# Altium schematic sheet LAN.SchDoc
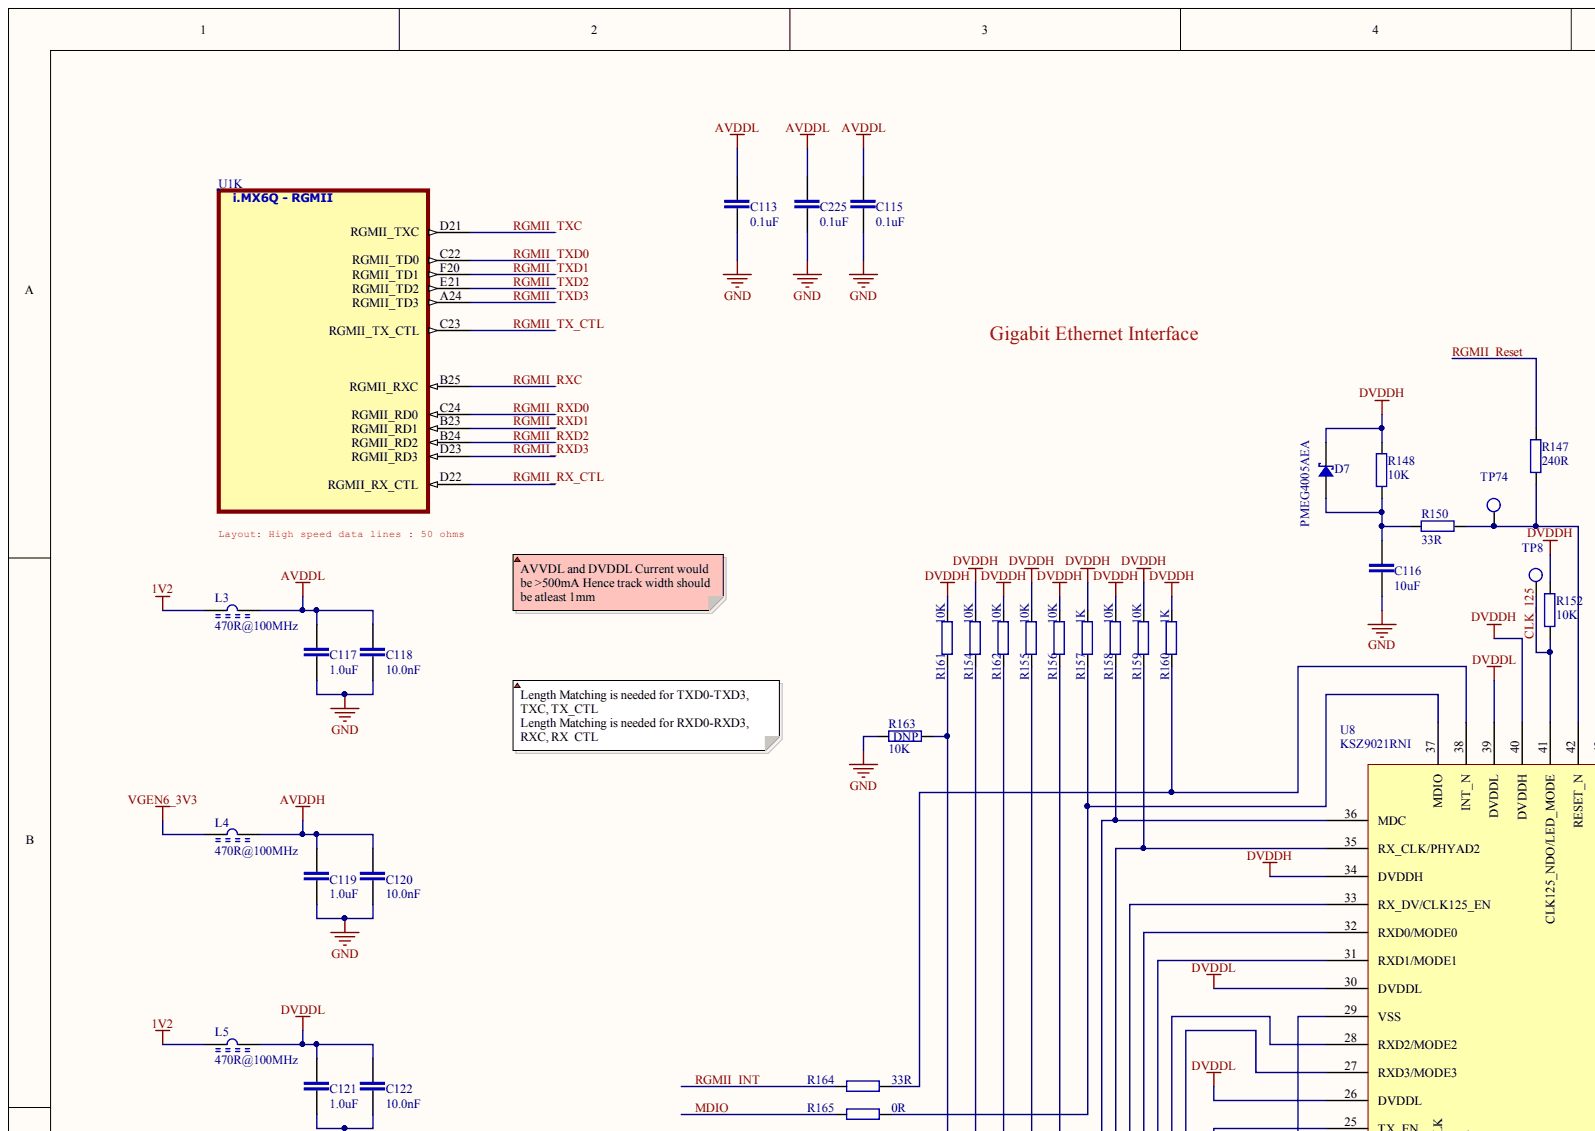
[diagram p0: LAN.SchDoc, top left]
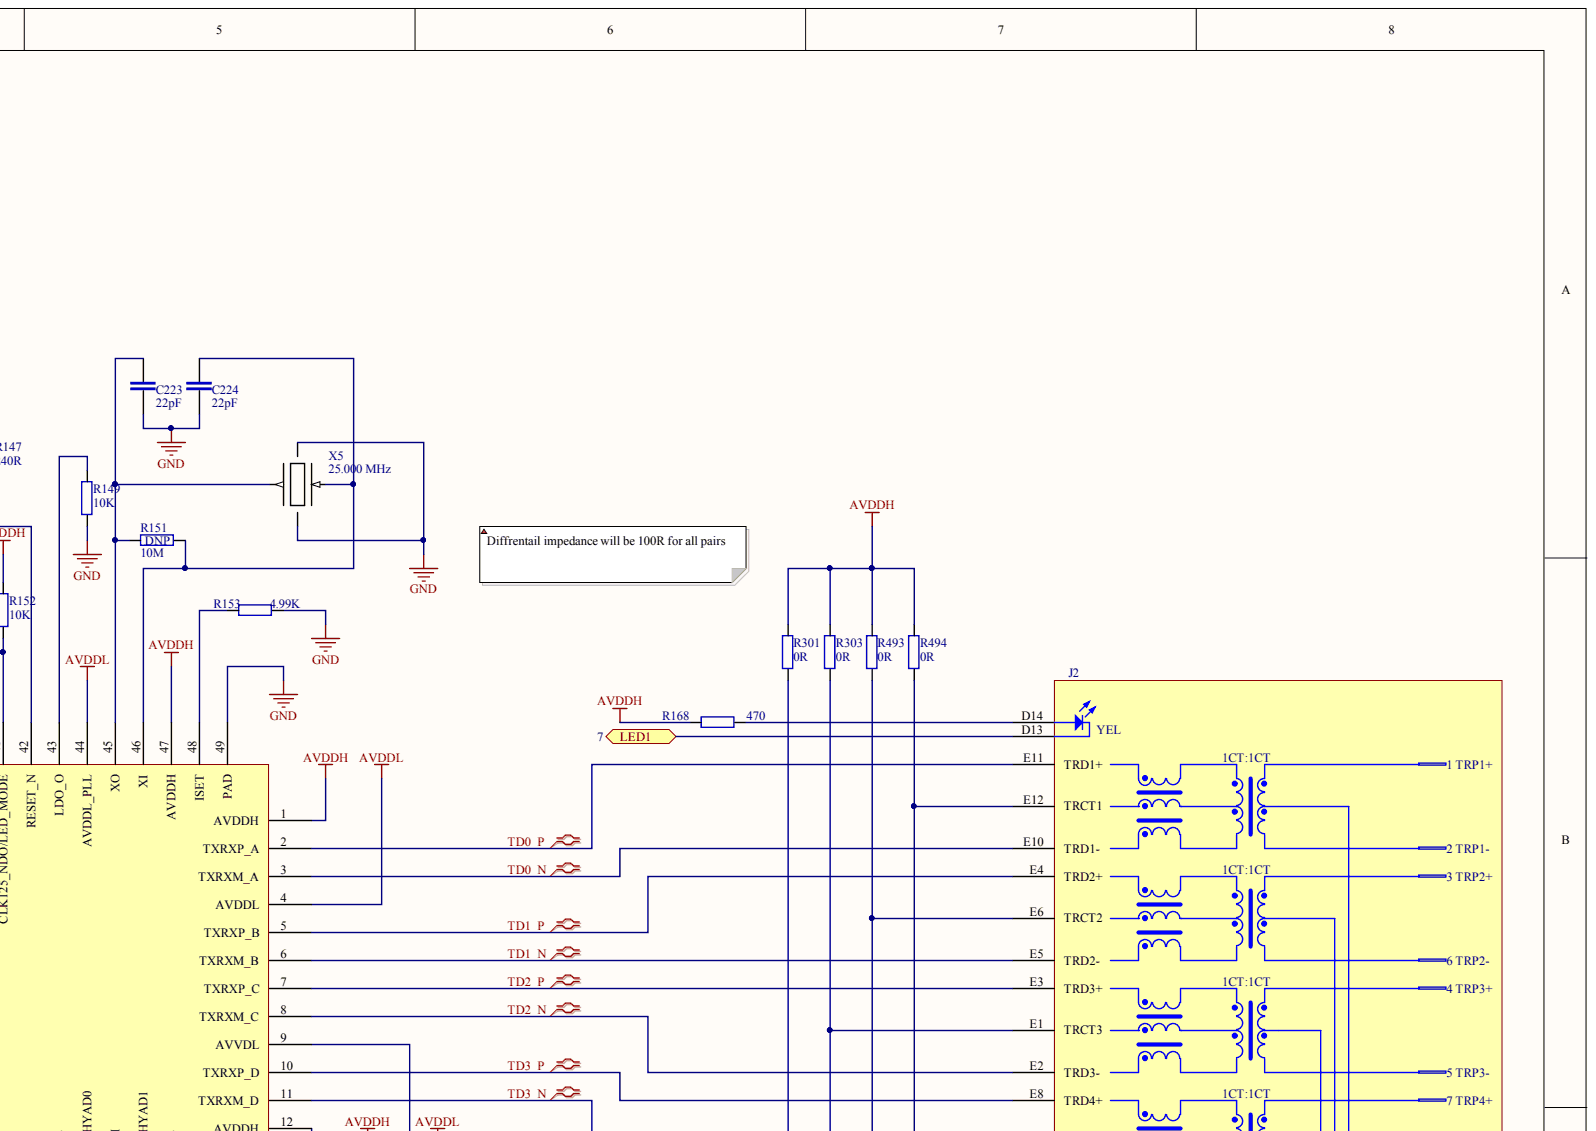
[diagram p1: LAN.SchDoc, top right]
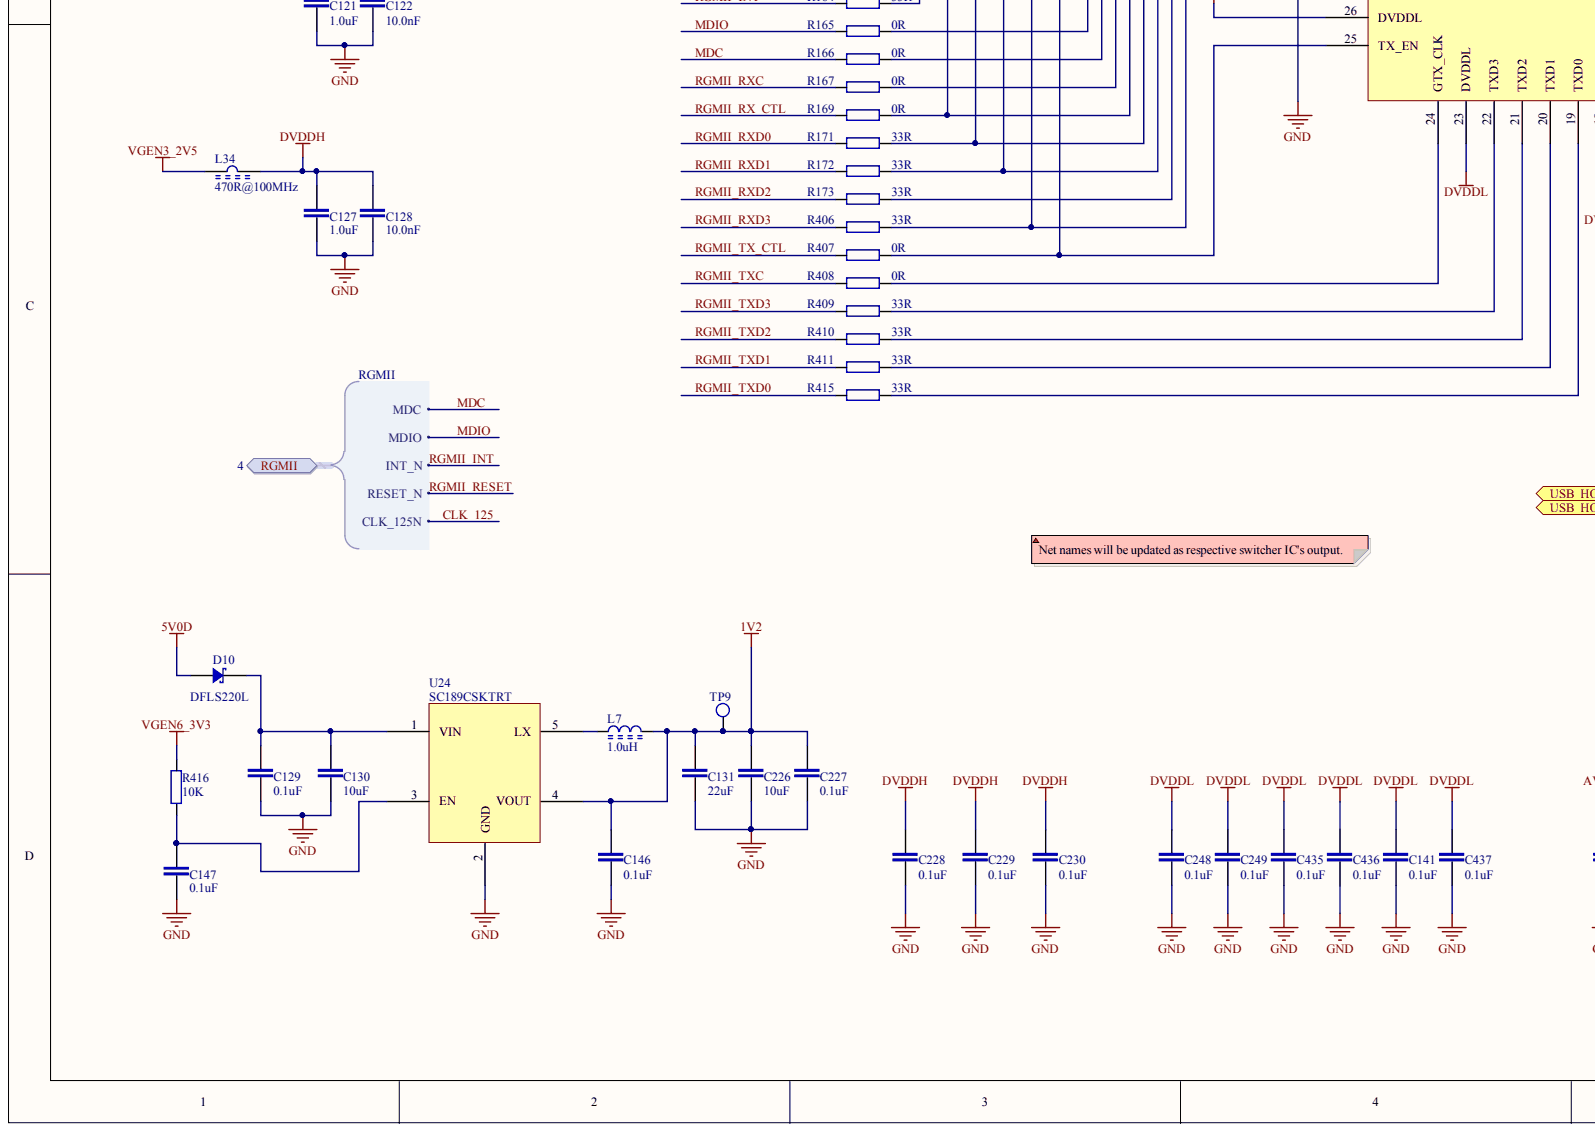
[diagram p2: LAN.SchDoc, bottom left]
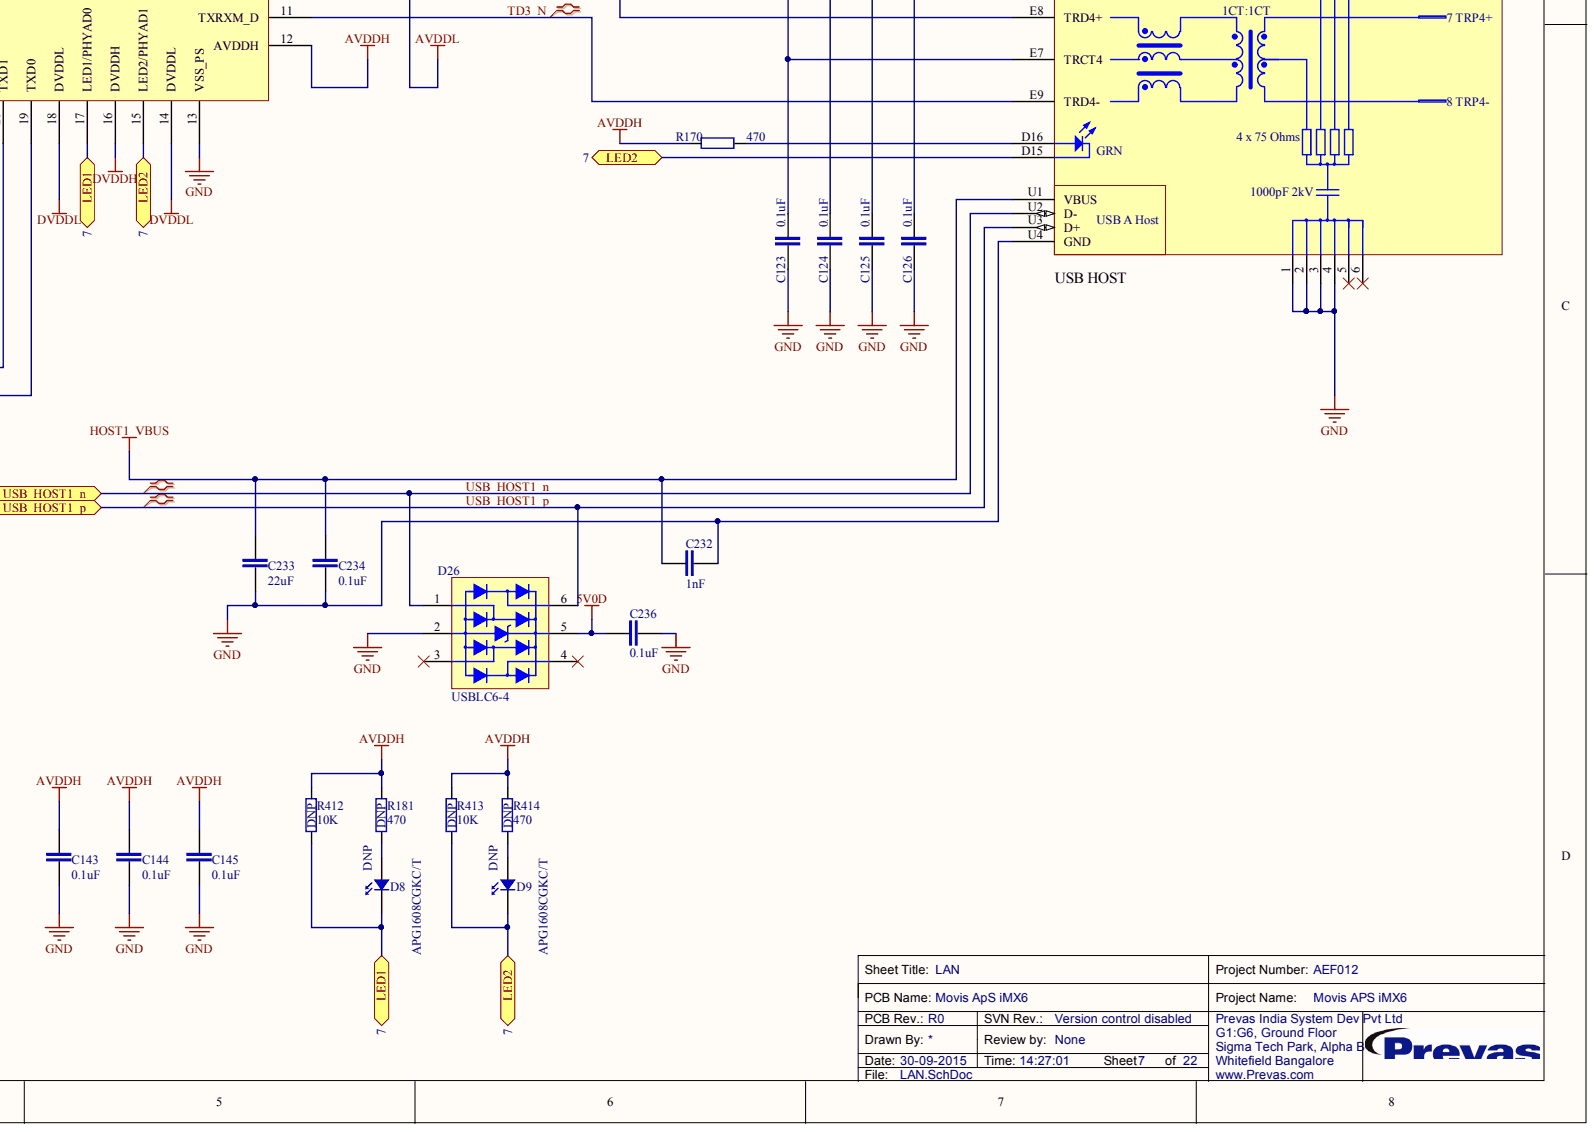
[diagram p3: LAN.SchDoc, bottom right]

## Parts
C113  0.1uF  [CAPC1005X55N]
C115  0.1uF  [CAPC1005X55N]
C116  10uF  [CAPC1608X95N]
C117  1.0uF  [CAPC1608X90N]
C118  10.0nF  [CAPC1005X55N]
C119  1.0uF  [CAPC1608X90N]
C120  10.0nF  [CAPC1005X55N]
C121  1.0uF  [CAPC1608X90N]
C122  10.0nF  [CAPC1005X55N]
C123  0.1uF  [CAPC1005X55N]
C124  0.1uF  [CAPC1005X55N]
C125  0.1uF  [CAPC1005X55N]
C126  0.1uF  [CAPC1005X55N]
C127  1.0uF  [CAPC1608X90N]
C128  10.0nF  [CAPC1005X55N]
C129  0.1uF  [CAPC1005X55N]
C130  10uF  [CAPC1608X95N]
C131  22uF  [CAPC3216X180N]
C141  0.1uF  [CAPC1005X55N]
C143  0.1uF  [CAPC1005X55N]
C144  0.1uF  [CAPC1005X55N]
C145  0.1uF  [CAPC1005X55N]
C146  0.1uF  [CAPC1005X55N]
C147  0.1uF  [CAPC1005X55N]
C223  22pF  [CAPC1005X55N]
C224  22pF  [CAPC1005X55N]
C225  0.1uF  [CAPC1005X55N]
C226  10uF  [CAPC1608X95N]
C227  0.1uF  [CAPC1005X55N]
C228  0.1uF  [CAPC1005X55N]
C229  0.1uF  [CAPC1005X55N]
C230  0.1uF  [CAPC1005X55N]
C232  1nF  [CAPC1005X55N]
C233  22uF  [CAPC3216X180N]
C234  0.1uF  [CAPC1005X55N]
C236  0.1uF  [CAPC1005X55N]
C248  0.1uF  [CAPC1005X55N]
C249  0.1uF  [CAPC1005X55N]
C435  0.1uF  [CAPC1005X55N]
C436  0.1uF  [CAPC1005X55N]
C437  0.1uF  [CAPC1005X55N]
D7  PMEG4005AEA  [SOD2512X110N]
D8  APG1608CGKC/T  Fitted: DNP  [Kingbright_APG1608CGKC-T]
D9  APG1608CGKC/T  Fitted: DNP  [Kingbright_APG1608CGKC-T]
D10  DFLS220L  [SODFL3718X100N]
D26  USBLC6-4  [SOT23-6L]
J2  0821-1X1T-43-F_1  [MagJack_43_1X1T+1USBA]  (value not drawn)
L3  470R@100MHz  [INDC4516X180N]
L4  470R@100MHz  [INDC4516X180N]
L5  470R@100MHz  [INDC4516X180N]
L7  1.0uH  [IND_LPS3015]
L34  470R@100MHz  [INDC4516X180N]
R147  240R  [RESC1608X55N]
R148  10K  [RESC1608X55N]
R149  10K  [RESC1608X55N]
R150  33R  [RESC1608X55N]
R151  10M  Fitted: DNP  [RESC1608X55N]
R152  10K  [RESC1608X55N]
R153  4.99K  [RESC1608X55N]
R154  10K  [RESC1608X55N]
R155  10K  [RESC1608X55N]
R156  10K  [RESC1608X55N]
R157  1K  [RESC1608X55N]
R158  10K  [RESC1608X55N]
R159  10K  [RESC1608X55N]
R160  1K  [RESC1608X55N]
R161  10K  [RESC1608X55N]
R162  10K  [RESC1608X55N]
R163  10K  Fitted: DNP  [RESC1608X55N]
R164  33R  [RESC1608X55N]
R165  0R  [RESC1608X55N]
R166  0R  [RESC1608X55N]
R167  0R  [RESC1608X55N]
R168  470  [RESC1608X55N]
R169  0R  [RESC1608X55N]
R170  470  [RESC1608X55N]
R171  33R  [RESC1608X55N]
R172  33R  [RESC1608X55N]
R173  33R  [RESC1608X55N]
R181  470  Fitted: DNP  [RESC1608X55N]
R301  0R  [RESC1608X55N]
R303  0R  [RESC1608X55N]
R406  33R  [RESC1608X55N]
R407  0R  [RESC1608X55N]
R408  0R  [RESC1608X55N]
R409  33R  [RESC1608X55N]
R410  33R  [RESC1608X55N]
R411  33R  [RESC1608X55N]
R412  10K  Fitted: DNP  [RESC1608X55N]
R413  10K  Fitted: DNP  [RESC1608X55N]
R414  470  Fitted: DNP  [RESC1608X55N]
R415  33R  [RESC1608X55N]
R416  10K  [RESC1608X55N]
R493  0R  [RESC1608X55N]
R494  0R  [RESC1608X55N]
TP8  Testpoint  [TP_SMD]  (value not drawn)  (no BOM)
TP9  Testpoint  [TP_SMD]  (value not drawn)  (no BOM)
TP74  Testpoint  [TP_SMD]  (value not drawn)  (no BOM)
U1  MCIMX6Q6AVT10AC  [BGA624C80P25X25_2100X2100X160]  (value not drawn)  (part K)
U8  KSZ9021RN  source_manufacturer_1_pn: KSZ9021RNI  [QFN50P700X700X90-49VKKD8N]
U24  SC189  Part Number: SC189CSKTRT  [SOT95P280X145-5N]
X5  25.000 MHz  [OSCCC320X500X110N]

## Ports
LED1, LED2, RGMII, RGMII.CLK_125N, RGMII.INT_N, RGMII.MDC, RGMII.MDIO, RGMII.RESET_N, USB_HOST1_N, USB_HOST1_P

## Nets
1V2: C131.2 C146.2 C226.2 C227.2 L3.1 L5.1 L7.2 TP9.1 U24.4(VOUT)
5V0D: C236.2 D10.A D26.5
AVDDH: C119.2 C120.2 C143.1 C144.1 C145.1 L4.2 R168.2 R170.2 R181.2 R301.2 R303.2 R412.1 R413.1 R414.2 R493.2 R494.2 U8.1(AVDDH) U8.12(AVDDH) U8.47(AVDDH)
AVDDL: C113.1 C115.1 C117.2 C118.2 C225.1 L3.2 U8.4(AVDDL) U8.9(AVVDL) U8.44(AVDDL_PLL)
DVDDH: C127.2 C128.2 C228.1 C229.1 C230.1 D7.C L34.2 R148.1 R152.1 R154.1 R155.1 R156.1 R157.2 R158.1 R159.1 R160.2 R161.1 R162.1 U8.16(DVDDH) U8.34(DVDDH) U8.40(DVDDH)
DVDDL: C121.2 C122.2 C141.1 C248.1 C249.1 C435.1 C436.1 C437.1 L5.2 U8.14(DVDDL) U8.18(DVDDL) U8.23(DVDDL) U8.26(DVDDL) U8.30(DVDDL) U8.39(DVDDL)
GND: C113.2 C115.2 C116.1 C117.1 C118.1 C119.1 C120.1 C121.1 C122.1 C123.2 C124.2 C125.2 C126.2 C127.1 C128.1 C129.1 C130.1 C131.1 C141.2 C143.2 C144.2 C145.2 C146.1 C147.1 C223.1 C224.1 C225.2 C226.1 C227.1 C228.2 C229.2 C230.2 C232.2 C233.1 C234.1 C236.1 C248.2 C249.2 C435.2 C436.2 C437.2 D26.2 J2.1 J2.2 J2.3 J2.4 J2.U4(GND) R149.2 R153.2 R163.1 U8.13(VSS_PS) U8.29(VSS) U8.49(PAD) U24.2(GND) X5.2 X5.4
HOST1_VBUS: C232.1 C233.2 C234.2 J2.U1(VBUS)
VGEN3_2V5: L34.1
VGEN6_3V3: L4.1 R416.2
CLK_125: R152.2 TP8.1 U8.41(CLK125_NDO/LED_MODE) ; port RGMII
LED1: D8.C J2.D13 R412.2 U8.17(LED1/PHYAD0) ; port LED1
LED2: D9.C J2.D15 R413.2 U8.15(LED2/PHYAD1) ; port LED2
MDC: R166.2 ; port RGMII
MDIO: R165.2 ; port RGMII
RGMII_INT: R164.1 ; port RGMII
RGMII_RESET: R147.2 ; port RGMII
RGMII_RX_CTL: R169.2 U1.D22(RGMII_RX_CTL)
RGMII_RXC: R167.2 U1.B25(RGMII_RXC)
RGMII_RXD0: R171.1 U1.C24(RGMII_RD0)
RGMII_RXD1: R172.1 U1.B23(RGMII_RD1)
RGMII_RXD2: R173.1 U1.B24(RGMII_RD2)
RGMII_RXD3: R406.1 U1.D23(RGMII_RD3)
RGMII_TX_CTL: R407.2 U1.C23(RGMII_TX_CTL)
RGMII_TXC: R408.2 U1.D21(RGMII_TXC)
RGMII_TXD0: R415.1 U1.C22(RGMII_TD0)
RGMII_TXD1: R411.1 U1.F20(RGMII_TD1)
RGMII_TXD2: R410.1 U1.E21(RGMII_TD2)
RGMII_TXD3: R409.1 U1.A24(RGMII_TD3)
TD0_N: J2.E10(TRD1-) U8.3(TXRXM_A)
TD0_P: J2.E11(TRD1+) U8.2(TXRXP_A)
TD1_N: J2.E5(TRD2-) U8.6(TXRXM_B)
TD1_P: J2.E4(TRD2+) U8.5(TXRXP_B)
TD2_N: J2.E2(TRD3-) U8.8(TXRXM_C)
TD2_P: J2.E3(TRD3+) U8.7(TXRXP_C)
TD3_N: J2.E9(TRD4-) U8.11(TXRXM_D)
TD3_P: J2.E8(TRD4+) U8.10(TXRXP_D)
USB_HOST1_N: D26.1 J2.U2(D-) ; port USB_HOST1_N
USB_HOST1_P: D26.6 J2.U3(D+) ; port USB_HOST1_P
NetC116_2: C116.2 D7.A R148.2 R150.1
NetC123_1: C123.1 J2.E7(TRCT4) R301.1
NetC124_1: C124.1 J2.E1(TRCT3) R303.1
NetC125_1: C125.1 J2.E6(TRCT2) R493.1
NetC126_1: C126.1 J2.E12(TRCT1) R494.1
NetC129_2: C129.2 C130.2 D10.C U24.1(VIN)
NetC147_2: C147.2 R416.1 U24.3(EN)
NetC223_2: C223.2 R151.1 U8.45(XO) X5.3
NetC224_2: C224.2 R151.2 U8.46(XI) X5.1
NetD8_A: D8.A R181.1
NetD9_A: D9.A R414.1
NetJ2_D14: J2.D14 R168.1
NetJ2_D16: J2.D16 R170.1
NetL7_1: L7.1 U24.5(LX)
NetR147_1: R147.1 R150.2 TP74.1 U8.42(RESET_N)
NetR149_1: R149.1 U8.43(LDO_O)
NetR153_1: R153.1 U8.48(ISET)
NetR154_2: R154.2 R171.2 U8.32(RXD0/MODE0)
NetR155_2: R155.2 R406.2 U8.27(RXD3/MODE3)
NetR156_2: R156.2 R407.1 U8.25(TX_EN)
NetR157_1: R157.1 R165.1 U8.37(MDIO)
NetR158_2: R158.2 R166.1 U8.36(MDC)
NetR159_2: R159.2 R167.1 U8.35(RX_CLK/PHYAD2)
NetR160_1: R160.1 R164.2 U8.38(INT_N)
NetR161_2: R161.2 R163.2 R169.1 U8.33(RX_DV/CLK125_EN)
NetR162_2: R162.2 R172.2 U8.31(RXD1/MODE1)
NetR173_2: R173.2 U8.28(RXD2/MODE2)
NetR408_1: R408.1 U8.24(GTX_CLK)
NetR409_2: R409.2 U8.22(TXD3)
NetR410_2: R410.2 U8.21(TXD2)
NetR411_2: R411.2 U8.20(TXD1)
NetR415_2: R415.2 U8.19(TXD0)

Not connected: D26 3 4; J2 5 6

## Library data of the parts
C113 C115 C123-C126 C129 C141 C143-C147 C225 C227-C230 C234 C236 C248 C249 C435-C437 = INNISI-73: CAP CER 0.1UF 50V 10% X5R 0402; ref_part_type: /capacitor/non_polarized/ceramic/; source_manufacturer_1: TDK Corporation; source_manufacturer_1_pn: C1005X5R1H104K050BB; cae_altium_pcb_status: Prototype; Database Table Name: altium_default; db_rev: 7569; elec_temp_range: -55 to +85 C; phy_height: 0.55mm; phy_mounting: SMD; phy_packaging_type: 0402; ref_datasheet: INNISI-71; cae_altium_sch_status: Prototype; Component Kind: Standard; ComponentLink1Description: Datasheet; ComponentLink1URL: https://cop201.prevas.dk/viewvc/datasheet/INNISI-71.pdf?root=hw_components&view=co; db_created: 2015-08-03 03:34:59.0; db_last_updated: 03-08-2015 03:35:01; db_local_id: INNISI-73; db_status: Prototype; elec_tolerance: ±10%; elec_value: 0.1uF; elec_voltage_rating: 50V; phy_material: X5R; ref_keyword: CAP CER 0.1UF 50V 10% X5R 0402; Library Name: altium_mysql.dblib
  C234 C236: Mounted: Yes
C116 C130 C226 = innisi-78: CAP CER 10UF 16V 10% X5R 0603; ref_part_type: /capacitor/non_polarized/ceramic/; source_manufacturer_1: Murata; source_manufacturer_1_pn: GRM188R61C106KAALD; cae_altium_pcb_status: Prototype; Database Table Name: altium_default; db_rev: 7574; elec_temp_range: -55 to +85C; phy_height: 0.95mm; phy_mounting: SMD; phy_packaging_type: 0603; ref_datasheet: INNISI-75; ref_keyword: Ceramic capacitor X5R 0603; cae_altium_sch_status: Prototype; Component Kind: Standard; ComponentLink1Description: Datasheet; ComponentLink1URL: https://cop201.prevas.dk/viewvc/datasheet/INNISI-75.pdf?root=hw_components&view=co; db_created: 2015-08-03 05:08:42.0; db_last_updated: 03-08-2015 05:08:44; db_local_id: INNISI-78; db_status: Prototype; elec_tolerance: 10%; elec_value: 10uF; elec_voltage_rating: 16V; phy_material: X5R; Library Name: altium_mysql.dblib
C117 C119 C121 C127 = INNISI-79: CAP CER 1UF 16V 10% X7R 0603; ref_part_type: /capacitor/non_polarized/ceramic/; source_manufacturer_1: Murata; source_manufacturer_1_pn: GRM188R71C105KE15D; cae_altium_pcb_status: Prototype; Database Table Name: altium_default; db_rev: 7576; elec_temp_range: -55 to +125; phy_height: 0.90mm; phy_mounting: SMD; phy_packaging_type: 0603 (1608 Metric); ref_datasheet: INNISI-33; ref_keyword: Ceramic Capacitor 0603; cae_altium_sch_status: Prototype; Component Kind: Standard; ComponentLink1Description: Datasheet; ComponentLink1URL: https://cop201.prevas.dk/viewvc/datasheet/INNISI-33.pdf?root=hw_components&view=co; db_created: 2015-08-03 05:39:06.0; db_last_updated: 03-08-2015 05:39:08; db_local_id: INNISI-79; db_status: Prototype; elec_tolerance: 10%; elec_value: 1.0uF; elec_voltage_rating: 16V; phy_material: X7R; Library Name: altium_mysql.dblib
C118 C120 C122 C128 = INNISI-82: CAP CER 10nF 50V 10% X7R 0402; ref_part_type: /capacitor/non_polarized/ceramic/; source_manufacturer_1: Yageo; source_manufacturer_1_pn: CC0402KRX7R9BB103; cae_altium_pcb_status: Prototype; Database Table Name: altium_default; db_rev: 7579; elec_temp_range: -55 to +125C; phy_height: 0.55mm; phy_mounting: SMD; phy_packaging_type: 0402; ref_datasheet: INNISI-72; ref_keyword: Ceramic capacitor; cae_altium_sch_status: Prototype; Component Kind: Standard; ComponentLink1Description: Datasheet; ComponentLink1URL: https://cop201.prevas.dk/viewvc/datasheet/INNISI-72.pdf?root=hw_components&view=co; db_created: 2015-08-03 05:52:48.0; db_last_updated: 03-08-2015 05:52:50; db_local_id: INNISI-82; db_status: Prototype; elec_tolerance: 10%; elec_value: 10.0nF; elec_voltage_rating: 50V; phy_material: X7R; Library Name: altium_mysql.dblib
C131 C233 = INNISI-77: CAP CER 22UF 25V 10% X5R 1206; ref_part_type: /capacitor/non_polarized/ceramic/; source_manufacturer_1: Murata Electronics; source_manufacturer_1_pn: GRM31CR61E226KE15L; cae_altium_pcb_status: Prototype; Database Table Name: altium_default; db_rev: 7573; elec_temp_range: -55 to +85 C; phy_height: 1.8mm; phy_mounting: SMD; phy_packaging_type: 1206; ref_datasheet: INNISI-74; ref_keyword: Multilayer chip capacitor; cae_altium_sch_status: Prototype; Component Kind: Standard; ComponentLink1Description: Datasheet; ComponentLink1URL: https://cop201.prevas.dk/viewvc/datasheet/INNISI-74.pdf?root=hw_components&view=co; db_created: 2015-08-03 04:56:21.0; db_last_updated: 03-08-2015 04:56:22; db_local_id: INNISI-77; db_status: Prototype; elec_tolerance: 10%; elec_value: 22uF; elec_voltage_rating: 25V; phy_material: X5R; Library Name: altium_mysql.dblib
C223 C224 = INNISI-83: CAP CER 22PF 50V 5% NP0 0402; ref_part_type: /capacitor/non_polarized/ceramic/; source_manufacturer_1: Murata; source_manufacturer_1_pn: GCM1555C1H220JA16D; cae_altium_pcb_status: Prototype; Database Table Name: altium_default; db_rev: 7582; elec_temp_range: -55 to +125C; phy_height: 0.55mm; phy_material: C0G; phy_mounting: SMD; phy_packaging_type: 0402; ref_datasheet: INNISI-76; ref_keyword: Ceramic capacitor; cae_altium_sch_status: Prototype; Component Kind: Standard; ComponentLink1Description: Datasheet; ComponentLink1URL: https://cop201.prevas.dk/viewvc/datasheet/INNISI-76.pdf?root=hw_components&view=co; db_created: 2015-08-03 06:17:55.0; db_last_updated: 03-08-2015 06:17:58; db_local_id: INNISI-83; db_status: Prototype; elec_tolerance: 5%; elec_value: 22pF; elec_voltage_rating: 50V; Library Name: altium_mysql.dblib
C232 = INNISI-84: CAP CER 1nF 50V 10% X7R 0402; ref_part_type: /capacitor/non_polarized/ceramic/; source_manufacturer_1: Murata; source_manufacturer_1_pn: GRM155R71H102KA01J; cae_altium_pcb_status: Prototype; Database Table Name: altium_default; db_rev: 7585; elec_temp_range: -55 to +125C; phy_height: 0.55mm; phy_mounting: SMD; phy_packaging_type: 0402; ref_datasheet: INNISI-73; ref_keyword: Ceramic capacitor X7R 0402; cae_altium_sch_status: Prototype; Component Kind: Standard; ComponentLink1Description: Datasheet; ComponentLink1URL: https://cop201.prevas.dk/viewvc/datasheet/INNISI-73.pdf?root=hw_components&view=co; db_created: 2015-08-03 06:26:19.0; db_last_updated: 03-08-2015 06:26:21; db_local_id: INNISI-84; db_status: Prototype; elec_tolerance: 10%; elec_value: 1nF; elec_voltage_rating: 50V; Mounted: Yes; phy_material: X7R; Library Name: altium_mysql.dblib
D7 = MASD-133: Diode Very low VF SOD323; ref_part_type: /diode/schottky/; source_manufacturer_1: Philips; cae_altium_pcb_status: Prototype; Database Table Name: altium_default; db_rev: 2165; elec_current_rating: 500mA; elec_temp_range: -65 to +150; elec_voltage_rating: 40V; phy_height: 1.1mm; phy_mounting: SMD; ref_datasheet: MASD-123; ref_keyword: Schottky Diode SMD; cae_altium_sch_status: Prototype; Component Kind: Standard; ComponentLink1Description: Datasheet; ComponentLink1URL: https://cop201.prevas.dk/viewvc/datasheet/MASD-123.pdf?root=hw_components&view=co; db_created: 2009-05-13 10:24:57; db_last_updated: 01-03-2010 14:47:58; db_local_id: MASD-133; db_status: Prototype; elec_value: PMEG4005AEA; phy_packaging_type: SOD323; source_manufacturer_1_pn: PMEG4005AEA; Library Name: altium_mysql.dblib
D8 D9 = GEPW-161: SMD LED, 570nm, 70mcd; ref_part_type: /optical/emmision/diode/; source_manufacturer_1: Kingbright; cae_altium_pcb_status: Prototype; Database Table Name: altium_default; db_rev: 3358; elec_current_rating: IF=20mA; elec_temp_range: -40 to 85; elec_voltage_rating: 2.1V; phy_height: 0.25; phy_packaging_type: 0603; ref_datasheet: GEPW-139; ref_keyword: Green; cae_altium_sch_status: Prototype; Component Kind: Standard; ComponentLink1Description: Datasheet; ComponentLink1URL: https://cop201.prevas.dk/viewvc/datasheet/GEPW-139.pdf?root=hw_components&view=co; db_created: 2011-04-26 11:17:00.0; db_last_updated: 26-04-2011 11:17:00; db_local_id: GEPW-161; db_status: Prototype; elec_frequency_rating: 570nm; elec_value: APG1608CGKC/T; phy_mounting: SMD; source_manufacturer_1_pn: APG1608CGKC/T; Library Name: altium_mysql.dblib
D10 = NIMA-225: Schottky Diode Rectifier, 2A; ref_part_type: /diode/schottky/; source_manufacturer_1: Diodes Inc; source_manufacturer_1_pn: DFLS220L-7; cae_altium_pcb_status: Prototype; Database Table Name: altium_default; db_rev: 2763; elec_temp_range: -55 to +125°C; elec_voltage_rating: 20V; phy_height: 1.0mm; phy_mounting: SMD; phy_packaging_type: PowerDI123; ref_datasheet: NIMA-165; ref_keyword: Schottky Diode SMD; cae_altium_sch_status: Prototype; Component Kind: Standard; ComponentLink1Description: Datasheet; ComponentLink1URL: https://cop201.prevas.dk/viewvc/datasheet/NIMA-165.pdf?root=hw_components&view=co; db_created: 2010-09-29 00:35:27.0; db_last_updated: 11-10-2010 13:07:30; db_local_id: NIMA-225; db_status: Prototype; elec_current_rating: 2A; elec_value: DFLS220L; Library Name: altium_mysql.dblib
D26 = NIMA-155: Dual very low capacitance ESD protection for USB 2.0 up to 480Mb/s; ref_part_type: /diode/transient/; source_manufacturer_1: STMicroelectronics; cae_altium_pcb_status: Prototype; Database Table Name: altium_default; db_rev: 1817; elec_temp_range: -40 to 125; elec_voltage_rating: 5V; phy_height: 1.45mm; phy_mounting: SMD; ref_datasheet: NIMA-115; cae_altium_sch_status: Prototype; Component Kind: Standard; ComponentLink1Description: Datasheet; ComponentLink1URL: https://cop201.prevas.dk/viewvc/datasheet/NIMA-115.pdf?root=hw_components&view=co; db_created: 2009-09-25 21:20:59; db_last_updated: 25-09-2009 21:21:20; db_local_id: NIMA-155; db_status: Prototype; elec_value: USBLC6-4; Mounted: Yes; phy_packaging_type: SOT23-6L; ref_keyword: ESD; source_manufacturer_1_pn: USBLC6-4; Library Name: altium_mysql.dblib
J2 = INNISI-29: CONN MAGJACK 2PORT 1000 BASE-T; ref_part_type: /connector/; source_manufacturer_1: Bel Fuse Inc; cae_altium_pcb_status: Prototype; Database Table Name: altium_default; db_rev: 7368; phy_mounting: Leaded; ref_keyword: RJ45 with USB A; cae_altium_sch_status: Prototype; Component Kind: Standard; ComponentLink1Description: Datasheet; ComponentLink1URL: https://cop201.prevas.dk/viewvc/datasheet/INNISI-29.pdf?root=hw_components&view=co; db_created: 2015-06-03 03:16:53.0; db_last_updated: 03-06-2015 03:16:55; db_local_id: INNISI-29; db_status: Prototype; elec_value: 0821-1X1T-43-F; ref_datasheet: INNISI-29; source_manufacturer_1_pn: 0821-1X1T-43-F; Library Name: altium_mysql.dblib
L3-L5 L34 = NIMA-36: Chip EMIFIL Inductor Type, 470R@100MHz, 0.05R@0Hz; ref_part_type: /inductor/lossy/; source_manufacturer_1: Murata; source_manufacturer_1_pn: BLM41PG471SN1L; cae_altium_pcb_status: Prototype; Database Table Name: altium_default; db_rev: 5393; elec_current_rating: 2.0A; elec_frequency_rating: 100MHz; elec_temp_range: -55 to 125; elec_tolerance: 25%; phy_height: 1.8mm; phy_material: Ferrite; phy_mounting: SMD; phy_packaging_type: 1806; ref_datasheet: NIMA-29; ref_keyword: Ferrite bead 1806; cae_altium_sch_status: Prototype; Component Kind: Standard; ComponentLink1Description: Datasheet; ComponentLink1URL: https://cop201.prevas.dk/viewvc/datasheet/NIMA-29.pdf?root=hw_components&view=co; db_created: 2009-03-20 21:04:27; db_last_updated: 05-12-2012 15:13:29; db_local_id: NIMA-36; db_status: Prototype; elec_value: 470R@100MHz; Library Name: altium_mysql.dblib
L7 = CHPE-247: Shielded Power Inductor; ref_part_type: /inductor/power/; source_manufacturer_1: Coilcraft; source_manufacturer_1_pn: LPS3015-102ML; cae_altium_pcb_status: Prototype; Database Table Name: altium_default; db_rev: 4393; elec_current_rating: 1.8A; elec_temp_range: -40 to +85°C; elec_tolerance: 20%; phy_height: 1.5mm; phy_material: Ferrite, copper; phy_mounting: SMD; ref_datasheet: GEPW-28; cae_altium_sch_status: Prototype; Component Kind: Standard; ComponentLink1Description: Datasheet; ComponentLink1URL: https://cop201.prevas.dk/viewvc/datasheet/GEPW-28.pdf?root=hw_components&view=co; db_created: 2012-03-13 14:03:37.0; db_last_updated: 13-03-2012 14:03:37; db_local_id: CHPE-247; db_status: Prototype; elec_value: 1.0uH; ref_keyword: Power inductor; Library Name: altium_mysql.dblib
R147 = INVIHA-10: RES SMD 240 OHM 1% 1/10W 0603; ref_part_type: /resistor/fixed/; source_manufacturer_1: Yageo; source_manufacturer_1_pn: RC0603FR-07240RL; cae_altium_pcb_status: Prototype; Database Table Name: altium_default; db_rev: 7589; elec_power_rating: 0.1W; elec_temp_range: -55 to +155° C; phy_height: 0.55mm; phy_packaging_type: 0603; ref_datasheet: INVIHA-2; ref_keyword: Chip Resistor; cae_altium_sch_status: Prototype; Component Kind: Standard; ComponentLink1Description: Datasheet; ComponentLink1URL: https://cop201.prevas.dk/viewvc/datasheet/INVIHA-2.pdf?root=hw_components&view=co; db_created: 2015-08-03 06:36:38.0; db_last_updated: 03-08-2015 6:36:40 AM; db_local_id: INVIHA-10; db_status: Prototype; elec_tolerance: 1%; elec_value: 240R; phy_mounting: SMD; Library Name: altium_mysql.dblib
R148 R149 R152 R154-R156 R158 R159 R161-R163 R412 R413 R416 = INVIHA-3: RES SMD 10K OHM 1% 1/10W 0603; ref_part_type: /resistor/fixed/; source_manufacturer_1: Yageo; source_manufacturer_1_pn: RC0603FR-0710KL; cae_altium_pcb_status: Prototype; Database Table Name: altium_default; db_rev: 7575; elec_power_rating: 0.1W; elec_temp_range: -55 to +155° C; phy_height: 0.55mm; phy_packaging_type: 0603; ref_datasheet: INVIHA-2; ref_keyword: Chip Resistor; cae_altium_sch_status: Prototype; Component Kind: Standard; ComponentLink1Description: Datasheet; ComponentLink1URL: https://cop201.prevas.dk/viewvc/datasheet/INVIHA-2.pdf?root=hw_components&view=co; db_created: 2015-08-03 05:39:03.0; db_last_updated: 03-08-2015 5:39:05 AM; db_local_id: INVIHA-3; db_status: Prototype; elec_tolerance: 1%; elec_value: 10K; phy_mounting: SMD; Library Name: altium_mysql.dblib
  R148 R149 R152 R154-R156 R158 R159 R161-R163 R412 R413: Mounted: Yes
R150 R164 R171-R173 R406 R409-R411 R415 = INVIHA-13: RES SMD 33 OHM 1% 1/10W 0603; ref_part_type: /resistor/fixed/; source_manufacturer_1: Yageo; source_manufacturer_1_pn: RC0603FR-0733RL; cae_altium_pcb_status: Prototype; Database Table Name: altium_default; db_rev: 7593; elec_power_rating: 0.1W; elec_temp_range: -55 to +155° C; phy_height: 0.55mm; phy_packaging_type: 0603; ref_datasheet: INVIHA-2; ref_keyword: Chip Resistor; cae_altium_sch_status: Prototype; Component Kind: Standard; ComponentLink1Description: Datasheet; ComponentLink1URL: https://cop201.prevas.dk/viewvc/datasheet/INVIHA-2.pdf?root=hw_components&view=co; db_created: 2015-08-03 06:49:16.0; db_last_updated: 03-08-2015 6:49:17 AM; db_local_id: INVIHA-13; db_status: Prototype; elec_tolerance: 1%; elec_value: 33R; phy_mounting: SMD; Library Name: altium_mysql.dblib
R151 = INVIHA-48: RES SMD 10M OHM 5% 1/10W 0603; ref_part_type: /resistor/fixed/; source_manufacturer_1: Yageo; source_manufacturer_1_pn: RC0603JR-0710ML; cae_altium_pcb_status: Prototype; Database Table Name: altium_default; db_rev: 7653; elec_power_rating: 0.1W; elec_temp_range: -55 to +155° C; phy_height: 0.55mm; phy_packaging_type: 0603; ref_datasheet: INVIHA-2; ref_keyword: Chip Resistor; cae_altium_sch_status: Prototype; Component Kind: Standard; ComponentLink1Description: Datasheet; ComponentLink1URL: https://cop201.prevas.dk/viewvc/datasheet/INVIHA-2.pdf?root=hw_components&view=co; db_created: 2015-08-04 04:48:09.0; db_last_updated: 04-08-2015 4:48:11 AM; db_local_id: INVIHA-48; db_status: Prototype; elec_tolerance: 5%; elec_value: 10M; phy_mounting: SMD; Library Name: altium_mysql.dblib
R153 = INVIHA-15: RES SMD 4.99K OHM 1% 1/10W 0603; ref_part_type: /resistor/fixed/; source_manufacturer_1: Yageo; source_manufacturer_1_pn: RC0603FR-074K99L; cae_altium_pcb_status: Prototype; Database Table Name: altium_default; db_rev: 7597; elec_power_rating: 0.1W; elec_temp_range: -55 to +155° C; phy_height: 0.55mm; phy_packaging_type: 0603; ref_datasheet: INVIHA-2; ref_keyword: Chip Resistor; cae_altium_sch_status: Prototype; Component Kind: Standard; ComponentLink1Description: Datasheet; ComponentLink1URL: https://cop201.prevas.dk/viewvc/datasheet/INVIHA-2.pdf?root=hw_components&view=co; db_created: 2015-08-03 06:59:19.0; db_last_updated: 03-08-2015 6:59:20 AM; db_local_id: INVIHA-15; db_status: Prototype; elec_tolerance: 1%; elec_value: 4.99K; phy_mounting: SMD; Library Name: altium_mysql.dblib
R157 R160 = INVIHA-11: RES SMD 1K OHM 1% 1/10W 0603; ref_part_type: /resistor/fixed/; source_manufacturer_1: Yageo; source_manufacturer_1_pn: RC0603FR-071KL; cae_altium_pcb_status: Prototype; Database Table Name: altium_default; db_rev: 7590; elec_power_rating: 0.1W; elec_temp_range: -55 to +155° C; phy_height: 0.55mm; phy_packaging_type: 0603; ref_datasheet: INVIHA-2; ref_keyword: Chip Resistor; cae_altium_sch_status: Prototype; Component Kind: Standard; ComponentLink1Description: Datasheet; ComponentLink1URL: https://cop201.prevas.dk/viewvc/datasheet/INVIHA-2.pdf?root=hw_components&view=co; db_created: 2015-08-03 06:39:44.0; db_last_updated: 03-08-2015 6:39:46 AM; db_local_id: INVIHA-11; db_status: Prototype; elec_tolerance: 1%; elec_value: 1K; phy_mounting: SMD; Library Name: altium_mysql.dblib
R165-R167 R169 R301 R303 R407 R408 R493 R494 = INVIHA-4: RES SMD 0.0 OHM JUMPER 1/10W; ref_part_type: /resistor/fixed/; source_manufacturer_1: Panasonic Electronic Components; source_manufacturer_1_pn: ERJ-3GEY0R00V; cae_altium_pcb_status: Prototype; Database Table Name: altium_default; db_rev: 7580; elec_power_rating: 0.1W; elec_temp_range: -55 to +155° C; phy_height: 0.55mm; phy_packaging_type: 0603; ref_datasheet: INVIHA-3; ref_keyword: Chip Resistor; cae_altium_sch_status: Prototype; Component Kind: Standard; ComponentLink1Description: Datasheet; ComponentLink1URL: https://cop201.prevas.dk/viewvc/datasheet/INVIHA-3.pdf?root=hw_components&view=co; db_created: 2015-08-03 05:59:19.0; db_last_updated: 03-08-2015 5:59:21 AM; db_local_id: INVIHA-4; db_status: Prototype; elec_value: 0R; phy_mounting: SMD; Library Name: altium_mysql.dblib
R168 R170 R181 R414 = SYS-2054: ref_part_type: /resistor/fixed/; source_manufacturer_1: Phycomp; source_manufacturer_1_pn: 2322704*4701L; cae_altium_pcb_status: Prototype; Database Table Name: altium_default; db_rev: 631; elec_current_rating: 1A; elec_power_rating: 0.1W; elec_temp_range: -55 to 155; elec_tolerance: 1%; elec_voltage_rating: 50V; phy_height: 0.55; phy_material: Thick film; phy_mounting: SMD; phy_packaging_type: 0603; ref_datasheet: CHKJ-6; ref_keyword: chip resistor; cae_altium_sch_status: Prototype; Component Kind: Standard; ComponentLink1Description: Datasheet; ComponentLink1URL: https://cop201.prevas.dk/viewvc/datasheet/CHKJ-6.pdf?root=hw_components&view=co; db_created: 2008-11-27 22:59:00; db_last_updated: 27-11-2008 23:03:46; db_local_id: SYS-2054; db_status: Prototype; elec_value: 470; Library Name: altium_mysql.dblib
TP8 TP9 TP74 = JEPE-114: Test Point SMD; ref_part_type: /pcb_item/; cae_altium_pcb_status: Prototype; Database Table Name: altium_default; db_rev: 3281; cae_altium_sch_status: Prototype; ComponentLink1Description: Datasheet; db_created: 2008-08-18 11:39:42; db_last_updated: 23-03-2011 14:14:06; db_local_id: JEPE-114; db_status: Prototype; elec_value: Test Point; phy_mounting: SMD; ref_keyword: Test  Point; Library Name: altium_mysql.dblib
U1 = INRAAN-5: i.MX 6Dual/6Quad; ref_part_type: /integrated/digital/processor/general/; AGILE_PN: 344-01218; CAD_APPROVED: Yes; cae_altium_pcb_status: Prototype; CLASS: IC; Database Table Name: altium_default; db_rev: 6247; elec_temp_range: -40 to +105; elec_voltage_rating: 1.5V; MFG_NAME01: FREESCALE SEMICONDUCTOR; phy_height: 1.85; phy_mounting: SMD; phy_packaging_type: FCPBGA96_624; ref_datasheet: INRAAN-6; cae_altium_sch_status: Prototype; Component Kind: Standard; ComponentLink1Description: Datasheet; ComponentLink1URL: https://cop201.prevas.dk/viewvc/datasheet/INRAAN-6.pdf?root=hw_components&view=co; db_created: 2013-10-21 12:25:57.0; db_last_updated: 27-12-2013 09:32:57; db_local_id: INRAAN-5; db_status: Prototype; elec_value: MCIMX6Q6AVT10AC; HEIGHT: 2.16MM; MFG_PN01: MCIMX6Q6AVT10AC; ref_keyword: i.MX 6Dual/6Quad; ROHS: Yes; source_manufacturer_1: Freescale Semiconductor; source_manufacturer_1_pn: MCIMX6Q6AVT10AC; Library Name: altium_mysql.dblib
U8 = INVIHA-1: Gigabit Ethernet Transceiver; ref_part_type: /integrated/digital/interface/ethernet/; source_manufacturer_1: Micron; cae_altium_pcb_status: Prototype; Database Table Name: altium_default; db_rev: 7501; elec_frequency_rating: 125Mhz Ref Clock; elec_temp_range: -40 to +85; elec_voltage_rating: 3.3V; phy_height: 0.8; phy_mounting: SMD; phy_packaging_type: QFN-48; ref_datasheet: INRAAN-5; cae_altium_sch_status: Prototype; Component Kind: Standard; ComponentLink1Description: Datasheet; ComponentLink1URL: https://cop201.prevas.dk/viewvc/datasheet/INRAAN-5.pdf?root=hw_components&view=co; db_created: 2015-07-06 11:20:22.0; db_last_updated: 06-07-2015 11:20:24 AM; db_local_id: INVIHA-1; db_status: Prototype; elec_value: KSZ9021RN; Part Number: KSZ9021RN; ref_keyword: Gigabit Ethernet Transceiver; Library Name: altium_mysql.dblib
U24 = INRAAN-2: 2.5MHz ,1.5A Synchronous Step Down Regulator; ref_part_type: /integrated/analog/power/supply/linear/linear/; source_manufacturer_1: SEMTECH; cae_altium_pcb_status: Prototype; Database Table Name: altium_default; db_rev: 6251; elec_temp_range: -40 to 85; elec_voltage_rating: 1.0 to 3.3 V; phy_height: 1.45; phy_mounting: SMD; phy_packaging_type: SOT; ref_keyword: Syncronous Step Down Regulator; cae_altium_sch_status: Prototype; Component Kind: Standard; ComponentLink1Description: Datasheet; ComponentLink1URL: https://cop201.prevas.dk/viewvc/datasheet/INRAAN-2.pdf?root=hw_components&view=co; db_created: 2013-10-21 09:03:29.0; db_last_updated: 27-12-2013 12:32:39; db_local_id: INRAAN-2; db_status: Prototype; elec_current_rating: 1.5A; elec_frequency_rating: 2.5MHz; elec_value: SC189; ref_datasheet: INRAAN-2; source_manufacturer_1_pn: SC189; Library Name: altium_mysql.dblib
X5 = GEPW-58: 25.000 MHz Crystal; ref_part_type: /oscillator/crystal/; source_manufacturer_1: ABRACON; source_manufacturer_1_pn: ABM3B-25.000MHZ-18-D-4-Y-T; cae_altium_pcb_status: Prototype; Database Table Name: altium_default; db_rev: 1284; elec_temp_range: -40 to 85; elec_tolerance: ±30ppm at 25 C deg, Load:18pF; phy_height: 1.1mm; phy_mounting: SMD; phy_packaging_type: 5x3.2mm; ref_datasheet: GEPW-45; cae_altium_sch_status: Prototype; Component Kind: Standard; ComponentLink1Description: Datasheet; ComponentLink1URL: https://cop201.prevas.dk/viewvc/datasheet/GEPW-45.pdf?root=hw_components&view=co; db_created: 2009-01-23 13:43:57; db_last_updated: 05-05-2009 16:32:24; db_local_id: GEPW-58; db_status: Prototype; elec_value: 25.000 MHz; ref_keyword: Crystal; Library Name: altium_mysql.dblib
